# Altium schematic sheet iWatch.SchDoc
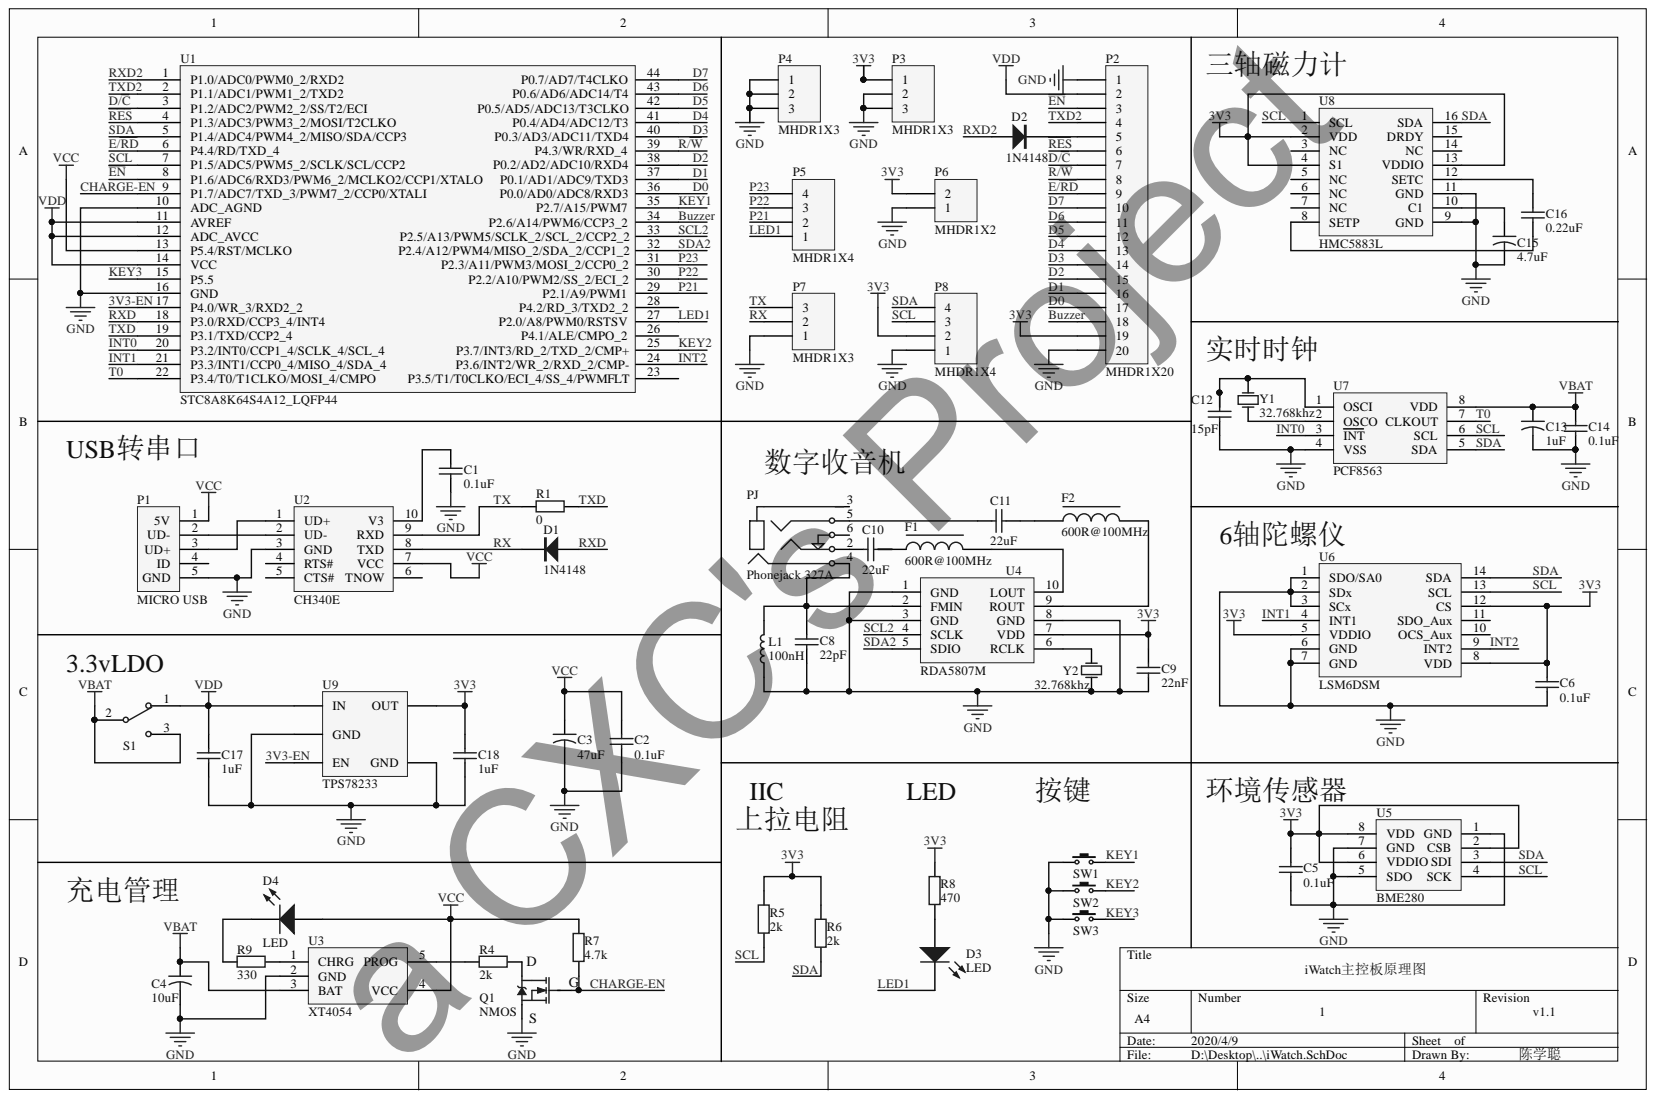
[diagram p0: iWatch.SchDoc]

## Parts
C1  0.1uF  [C-0603-M]
C2  0.1uF  [C-0603-M]
C3  47uF  [C-钽电容-3216-N]
C4  10uF  [C-钽电容-3216-N]
C5  0.1uF  [C-0603-M]
C6  0.1uF  [C-0603-M]
C8  22pF  [C-0603-M]
C9  22nF  [C-0603-M]
C10  22uF  [C-0603-M]
C11  22uF  [C-0603-M]
C12  15pF  [C-0603-M]
C13  1uF  [C-钽电容-3216-N]
C14  0.1uF  [C-0603-M]
C15  4.7uF  [C-钽电容-3216-N]
C16  0.22uF  [C-0603-M]
C17  1uF  [C-0603-M]
C18  1uF  [C-0603-M]
D1  1N4148  [SOD-323/0805]
D2  1N4148  [SOD-323/0805]
D3  LED  [LED-0603-white-M]
D4  LED  [LED-0603-Red-N]
F1  600R@100MHz  [C-0603-M]
F2  600R@100MHz  [C-0603-M]
L1  100nH  [C-0603-M]
P1  MICRO USB  [MICRO-USB-牛角插板-无卷边]
P2  MHDR1X20  [MHDR1X20]
P3  MHDR1X3  [MHDR1X3]
P4  MHDR1X3  [MHDR1X3]
P5  MHDR1X4  [MHDR1X4 - SMT]
P6  MHDR1X2  [MHDR1X2 - SMT]
P7  MHDR1X3  [MHDR1X3 - SMT]
P8  MHDR1X4  [MHDR1X4 - SMT]
PJ  Phonejack 327A  [PJ-327A - 嵌入]
Q1  NMOS  [SOT-23]
R1  0  [R-0603-M]
R4  2k  [R-0603-M]
R5  2k  [R-0603-M]
R6  2k  [R-0603-M]
R7  4.7k  [R-0603-M]
R8  470  [R-0603-M]
R9  330  [R-0603-M]
S1  SS-12F23  [MK-12C02]  (value not drawn)
SW1  TSW  [SB-2*4*3.5 - no hole]  (value not drawn)
SW2  TSW  [SB-2*4*3.5 - no hole]  (value not drawn)
SW3  TSW  [SB-2*4*3.5 - no hole]  (value not drawn)
U1  STC8A8K64S4A12_LQFP44  [LQFP44 10X10_L]
U2  CH340E  [MSOP10]
U3  XT4054  [SOT23-5]
U4  RDA5807M  [MSOP10]
U5  BME280  [LGA-8]
U6  LSM6DSM  [LGA-14L]
U7  PCF8563  [TSSOP8_M]
U8  HMC5883L  [HMC5883L]
U9  TPS78233  [SOT23-5]
Y1  32.768khz  [FC135]
Y2  32.768khz  [FC135]

## Nets
3V3: C5.1 C6.1 C9.1 C18.1 P2.19 P3.1 P6.2 P8.2 R5.2 R6.2 R8.2 U4.7 U5.2 U5.6 U5.8 U6.5 U6.8 U6.12 U8.2 U8.4 U8.13 U9.5
GND: C1.2 C2.2 C3.2 C4.2 C5.2 C6.2 C8.2 C9.2 C12.2 C13.2 C14.2 C15.2 C17.2 C18.2 L1.1 P1.5 P2.1 P2.20 P3.2 P3.3 P4.1 P4.2 P4.3 P6.1 P7.1 P8.1 Q1.2 SW1.1 SW2.1 SW3.1 U1.10 U1.16 U2.3 U3.2 U4.1 U4.3 U4.8 U5.1 U5.5 U5.7 U6.1 U6.2 U6.3 U6.6 U6.7 U7.4 U8.9 U8.11 U9.2 U9.4 Y2.1
VBAT: C4.1 C13.1 C14.1 S1.2 S1.3 U3.3 U7.8
VCC: C2.1 C3.1 D4.1 P1.1 R7.2 U1.13 U2.7 U3.4
VDD: C17.1 P2.2 S1.1 U1.11 U1.12 U1.14 U9.1
3V3-EN: U1.17 U9.3
BUZZER: P2.18 U1.34
CHARGE-EN: Q1.1 R7.1 U1.9
D0: P2.17 U1.36
D1: P2.16 U1.37
D2: P2.15 U1.38
D3: P2.14 U1.40
D4: P2.13 U1.41
D5: P2.12 U1.42
D6: P2.11 U1.43
D7: P2.10 U1.44
D/~{C}: P2.7 U1.3
E/~{RD}: P2.9 U1.6
~{EN}: P2.3 U1.8
INT0: U1.20 U7.3
INT1: U1.21 U6.4
INT2: U1.24 U6.9
KEY1: SW1.2 U1.35
KEY2: SW2.2 U1.25
KEY3: SW3.2 U1.15
LED1: D3.2 P5.1 U1.27
P21: P5.2 U1.29
P22: P5.3 U1.30
P23: P5.4 U1.31
R/~{W}: P2.8 U1.39
~{RES}: P2.6 U1.4
RX: D1.2 P7.2 U2.8
RXD: D1.1 U1.18
RXD2: D2.1 U1.1
SCL: P8.3 R5.1 U1.7 U5.4 U6.13 U7.6 U8.1
SCL2: U1.33 U4.4
SDA: P8.4 R6.1 U1.5 U5.3 U6.14 U7.5 U8.16
SDA2: U1.32 U4.5
T0: U1.22 U7.7
TX: P7.3 R1.1 U2.9
TXD: R1.2 U1.19
TXD2: P2.4 U1.2
NetC1_1: C1.1 U2.10
NetC8_1: C8.1 L1.2 PJ.4 U4.2
NetC10_1: C10.1 PJ.2
NetC10_2: C10.2 F1.2
NetC11_1: C11.1 PJ.5
NetC11_2: C11.2 F2.2
NetC12_1: C12.1 U7.1 Y1.1
NetC15_1: C15.1 U8.10
NetC16_1: C16.1 U8.12
NetC16_2: C16.2 U8.8
NetD2_2: D2.2 P2.5
NetD3_1: D3.1 R8.1
NetD4_2: D4.2 R9.1
NetF1_1: F1.1 U4.10
NetF2_1: F2.1 U4.9
NetP1_2: P1.2 U2.2
NetP1_3: P1.3 U2.1
NetQ1_3: Q1.3 R4.1
NetR4_2: R4.2 U3.5
NetR9_2: R9.2 U3.1
NetU4_6: U4.6 Y2.2
NetU7_2: U7.2 Y1.2

Not connected: P1 4; PJ 3 6; U1 23 26 28; U2 4 5 6; U6 10 11; U8 3 5 6 7 14 15

## Library data of the parts
C1 C2 C5 C6 C8-C12 C14 C16-C18 = Cap: 陶瓷电容; Component Kind: Standard; LatestRevisionDate: 17-Jul-2002; LatestRevisionNote: Re-released for DXP Platform.; PackageDescription: Radial Cap, Thru-Hole; 2 Leads; 0.3 in Pin Spacing; PackageReference: RAD-0.3; Published: 8-Jun-2000; Signal Integrity: Cap; Simulation: CAP; Library Name: cxc's integrated library.IntLib; Publisher: Altium Limited
C3 C4 C13 C15 = Cap2: 电解电容; Component Kind: Standard; PackageDescription: Capacitor; 2 Leads; PackageReference: CAPR5-4X5; Published: 23-Sep-2002; Signal Integrity: Cap; Simulation: CAP; Library Name: cxc's integrated library.IntLib; Publisher: Altium Limited
D1 D2 = 1N4148: 高速开关二极管; 版权归属: 源创客; BOM名称: 高速开关二极管; Component Kind: Standard; 学习资源: www.ooocke.com; Library Name: cxc's integrated library.IntLib
D3 D4 = LED: Typical RED, GREEN, YELLOW, AMBER GaAs LED; Component Kind: Standard; LatestRevisionDate: 17-Jul-2002; LatestRevisionNote: Re-released for DXP Platform.; PackageDescription: SM LED; 2 Flat Leads; PackageReference: 3.2X1.6X1.1; PackageVersion: Feb-2002; Published: 8-Jun-2000; Simulation: LED2; Library Name: cxc's integrated library.IntLib; Publisher: Altium Limited
F1 F2 = Inductance2: Component Kind: Standard; Library Name: cxc's integrated library.IntLib
L1 = Inductance1: Component Kind: Standard; Library Name: cxc's integrated library.IntLib
P1 = MICRO_USB: MICRO USB接口; Component Kind: Standard; Library Name: cxc's integrated library.IntLib
P2 = MHDR1X20: Header, 20-Pin; Component Kind: Standard; LatestRevisionDate: 17-Jul-2002; LatestRevisionNote: Re-released for DXP Platform.; Signal Integrity: Connector; Library Name: cxc's integrated library.IntLib; Publisher: Altium Limited
P3 P4 P7 = MHDR1X3: Header, 3-Pin; Component Kind: Standard; LatestRevisionDate: 17-Jul-2002; LatestRevisionNote: Re-released for DXP Platform.; Signal Integrity: Connector; Library Name: cxc's integrated library.IntLib; Publisher: Altium Limited
P5 P8 = MHDR1X4: Header, 4-Pin; Component Kind: Standard; LatestRevisionDate: 17-Jul-2002; LatestRevisionNote: Re-released for DXP Platform.; Signal Integrity: Connector; Library Name: cxc's integrated library.IntLib; Publisher: Altium Limited
P6 = MHDR1X2: Header, 2-Pin; Component Kind: Standard; LatestRevisionDate: 17-Jul-2002; LatestRevisionNote: Re-released for DXP Platform.; Signal Integrity: Connector; Library Name: cxc's integrated library.IntLib; Publisher: Altium Limited
Q1 = NMOS: N沟道场效应管; 版权归属: 源创客; BOM名称: N沟道场效应管; Component Kind: Standard; 学习资源: www.ooocke.com; Library Name: cxc's integrated library.IntLib
R1 R4-R9 = Resistor: Component Kind: Standard; Description: Resistor; LatestRevisionDate: 17-Jul-2002; LatestRevisionNote: Re-released for DXP Platform.; PackageDescription: Axial Device, Thru-Hole; 2 Leads; 0.4 in Pin Spacing; PackageReference: AXIAL-0.4; Published: 8-Jun-2000; Signal Integrity: Res; Simulation: RESISTOR; Library Name: cxc's integrated library.IntLib; Publisher: Altium Limited
S1 = SSW-1P: 1路波动开关; BOM名称: 波动开关; 版权归属: 源创客; Component Kind: Standard; 学习资源: www.ooocke.com; Library Name: cxc's integrated library.IntLib
SW1-SW3 = TSW: 3x6轻触开关; 版权归属: 源创客; BOM名称: 3x6轻触开关; Component Kind: Standard; 学习资源: www.ooocke.com; Library Name: cxc's integrated library.IntLib
U2 = CH340E: USB转串口，免外部晶振，超小封装; Component Kind: Standard; Library Name: cxc's integrated library.IntLib
U3 = XT4054: 锂电池充电ic; Component Kind: Standard; Library Name: cxc's integrated library.IntLib
U4 = RDA5807M: 数字收音机芯片; Component Kind: Standard; Library Name: cxc's integrated library.IntLib
U5 = BME280: Combined humidity and pressure sensor; Component Kind: Standard; Library Name: cxc's integrated library.IntLib
U6 = LSM6DSM: always-on 3D accelerometer and 3D gyroscope; Component Kind: Standard; Library Name: cxc's integrated library.IntLib
U7 = PCF8563TS: Real-Time Clock and calendar; Component Kind: Standard; Library Name: cxc's integrated library.IntLib
U8 = HMC5883L: 高精度三轴磁力计; Component Kind: Standard; Library Name: cxc's integrated library.IntLib
U9 = TPS78233: LDO-3.3v; Component Kind: Standard; Library Name: cxc's integrated library.IntLib
Y1 Y2 = XTAL: Crystal Oscillator; Component Kind: Standard; LatestRevisionDate: 17-Jul-2002; LatestRevisionNote: Re-released for DXP Platform.; PackageDescription: Crystal, Thru-Hole; 2 Leads; Body 3.1 x 8.2 mm (Dia.xH); PackageReference: R38; Published: 8-Jun-2000; Simulation: XTAL; Library Name: cxc's integrated library.IntLib; Publisher: Altium Limited
PJ = Phonejack 327A: Component Kind: Standard; Library Name: cxc's integrated library.IntLib
U1 = STC8A8K64S4A12_LQFP44: Component Kind: Standard; Library Name: cxc's integrated library.IntLib
